# Altium schematic sheet TEST-MODULE.SchDoc(TEST-MODULE)
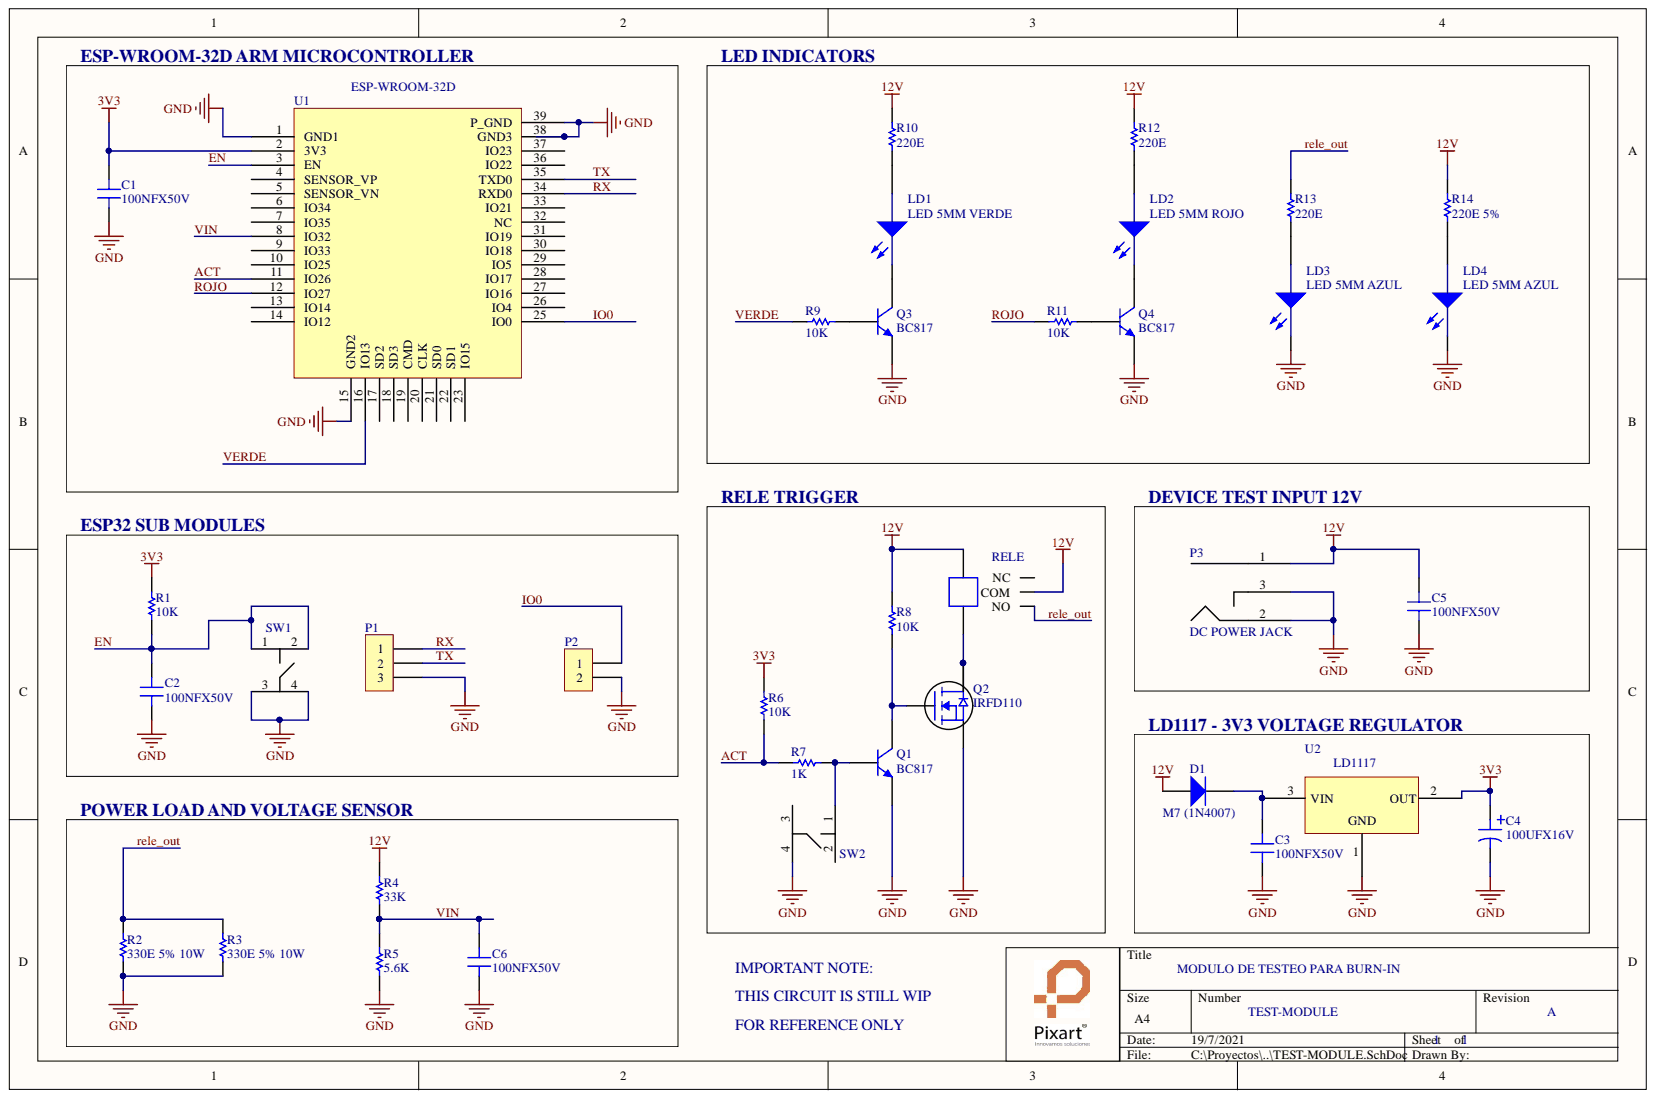
[diagram p0: TEST-MODULE.SchDoc]

## Parts
C1  100NFX50V  [CAP.SMD.0805]
C2  100NFX50V  [CAP.SMD.0805]
C3  100NFX50V  [CAP.SMD.0805]
C4  100UFX16V  [CAP-ELEC-SMD]
C5  100NFX50V  [CAP.SMD.0805]
C6  100NFX50V  [CAP.SMD.0805]
D1  M7 (1N4007)  [Diode-SMD-SMA]
LD1  LED 5MM VERDE  [LED-5MM-ALTO-9-VERDE]
LD2  LED 5MM ROJO  [LED-5MM-ALTO-9-ROJO]
LD3  LED 5MM AZUL  [LED-5MM-ALTO-9-AZUL]
LD4  LED 5MM AZUL  [LED-5MM-ALTO-9-AZUL]
P1  IDC-MA-THT-1X3-P2.54MM-L11  [IDC-MA-THT-1X3-P2.54MM-L11]  (value not drawn)
P2  IDC-MA-THT-1X2-P2.54MM-L11  [IDC-MA-THT-1X2-P2.54MM-L11]  (value not drawn)
P3  DC POWER JACK  [VAR-DC-JACK - VERT]
Q1  BC817  [NPN.SMD.SOT23]
Q2  IRFD110  [TRA-IRFD110]
Q3  BC817  [NPN.SMD.SOT23]
Q4  BC817  [NPN.SMD.SOT23]
R1  10K  [RES.SMD.103]
R2  330E 5% 10W  [RES-CERAMICA-10W]
R3  330E 5% 10W  [RES-CERAMICA-10W]
R4  33K  [RES.SMD.333]
R5  5.6K  [RES.SMD.562]
R6  10K  [RES.SMD.103]
R7  1K  [RES.SMD.102]
R8  10K  [RES.SMD.103]
R9  10K  [RES.SMD.103]
R10  220E  [RES.SMD.221]
R11  10K  [RES.SMD.103]
R12  220E  [RES.SMD.221]
R13  220E  [RES.SMD.221]
R14  220E 5%  [RES.SMD.221]
RELE  Relay simple inversor 12V 12A  [RELAY 12V 12A CHICO]  (value not drawn)
SW1  TACT-SWITCH-THT-4C-06X06(5MM)  [TACT-SWITCH-THT-4C-06X06-5MM]  (value not drawn)
SW2  TACT-SWITCH-THT-4C-06X06(13MM)-JIANFU  [TACT-SWITCH-THT-4C-06X06-13MM]  (value not drawn)
U1  ESP32-WROOM-32D  [ESP32-WROOM-32D]  (value not drawn)
U2  LD1117S33TR SMD DPAK 0.8A 3.3V LDO LINEAR REGULATOR  [DPAK]  (value not drawn)

## Nets
3V3: C1.2 C4.2 R1.2 R6.2 U1.2(3V3) U2.2
12V: C5.2 D1.A P3.1 R4.2 R8.2 R10.2 R12.2 R14.2 RELE.1 RELE.3(COM)
GND: C1.1 C2.1 C3.1 C4.1 C5.1 C6.1 LD3.K LD4.K P1.3 P2.2 P3.2 P3.3 Q1.2 Q2.4 Q3.2 Q4.2 R2.1 R3.1 R5.1 SW1.3 SW1.4 SW2.4 U1.1(GND1) U1.15(GND2) U1.38(GND3) U1.39(P_GND) U2.1
ACT: R6.1 R7.1 U1.11(IO26)
EN: C2.2 R1.1 SW1.1 SW1.2 U1.3(EN)
IO0: P2.1 U1.25(IO0)
rele_out: R2.2 R3.2 R13.2 RELE.4(NO)
ROJO: R11.2 U1.12(IO27)
RX: P1.1 U1.34(RXD0)
TX: P1.2 U1.35(TXD0)
VERDE: R9.1 U1.16(IO13)
VIN: C6.2 R4.1 R5.2 U1.8(IO32)
NetC3_2: C3.2 D1.K U2.3
NetLD1_A: LD1.A R10.1
NetLD1_K: LD1.K Q3.3
NetLD2_A: LD2.A R12.1
NetLD2_K: LD2.K Q4.3
NetLD3_A: LD3.A R13.1
NetLD4_A: LD4.A R14.1
NetQ1_1: Q1.1 R7.2 SW2.1
NetQ1_3: Q1.3 Q2.3 R8.1
NetQ2_1: Q2.1 Q2.2 RELE.2
NetQ3_1: Q3.1 R9.2
NetQ4_1: Q4.1 R11.1

Not connected: RELE 5(NC); SW2 2 3; U1 4(SENSOR_VP) 5(SENSOR_VN) 6(IO34) 7(IO35) 9(IO33) 10(IO25) 13(IO14) 14(IO12) 17(SD2) 18(SD3) 19(CMD) 20(CLK) 21(SD0) 22(SD1) 23(IO15) 26(IO4) 27(IO16) 28(IO17) 29(IO5) 30(IO18) 31(IO19) 32(NC) 33(IO21) 36(IO22) 37(IO23)

## Library data of the parts
C1-C3 C5 C6 = Capacitor 100NFX50V X7R 10% CER.MULT. SMD 0805: 100NFX50V X7R 10% CER.MULT. SMD 0805; COD. FV: CE.CAP.0008; COD. ELEMON: CA3279774; Library Name: Libreria-Capacitores.IntLib; Component Kind: Standard
C4 = Capacitor Electrolitico SMD 100UFX16V 20%. 6.3x5.3 ELE.RAD.SMD [R=]: 100UFX16V 20%. 6.3x5.3 ELE.RAD.SMD [R=]; COD. FV: CE.CAP.0006; COD. ELEMON: CA3629130; Library Name: Libreria-Capacitores.IntLib; Component Kind: Standard
D1 = Diodo M7 DIODO 1A 1000V SMD-SMA (1N4007) XYG: M7 DIODO 1A 1000V SMD-SMA (1N4007) XYG; COD. ELEMON: DI1202221; Library Name: Libreria-Diodos.IntLib; Component Kind: Standard
LD1 = LED 5MM VERDE ALTO 9: Library Name: Libreria-LEDs.IntLib; Description: LED 5MM VERDE ALTO 9; Component Kind: Standard
LD2 = LED 5MM ROJO ALTO 9: Library Name: Libreria-LEDs.IntLib; Description: LED 5MM ROJO ALTO 9; Component Kind: Standard
LD3 LD4 = LED 5MM AZUL ALTO 9: Library Name: Libreria-LEDs.IntLib; Description: LED 5MM AZUL ALTO 9; Component Kind: Standard
P1 = IDC-MA-THT-1X3-P2.54MM-L11: COD. ELEMON: CO496C20; Library Name: Libreria-Conectores.IntLib; Description: IDC-MA-THT-1X3-P2.54MM-L11; Component Kind: Standard
P2 = IDC-MA-THT-1X2-P2.54MM-L11: COD. ELEMON: CO496C20; Library Name: Libreria-Conectores.IntLib; Description: IDC-MA-THT-1X2-P2.54MM-L11; Component Kind: Standard
P3 = DC POWER JACK VERTICAL 2MM: Description: DC POWER JACK VERTICAL 2MM; Component Kind: Standard; Library Name: Libreria-Varios.IntLib
Q1 Q3 Q4 = Transistor BC817-40 TRA.NPN 45V0.5A 0.25W SOT23: BC817-40 TRA.NPN 45V0.5A 0.25W SOT23; COD. FV: CE.TRA.0002; COD. ELEMON: TR1723020; Library Name: Libreria-Transistores.IntLib; Component Kind: Standard
Q2 = Transistor MOSFET N IRFD110: COD. FV: CE.TRA.0003; Library Name: Libreria-Transistores.IntLib; Description: Transistor MOSFET N IRFD110; Component Kind: Standard
R1 R6 R8 R9 R11 = Resistencia 10K 5% 0805 1/8W: 10K 5% 0805 1/8W RES.SMD; COD. FV: CE.RES.0014; COD. ELEMON: RS3896245; Component Kind: Standard; Library Name: Libreria-Resistencias.IntLib
R2 R3 = Resistencia Ceramica 330E 5% 10W SE15 RES.CEM: COD. ELEMON: RS4096155; Description: Resistencia Ceramica 330E 5% 10W SE15 RES.CEM; Component Kind: Standard; Library Name: Libreria-Resistencias.IntLib
R4 = Resistencia 33K 5% 0805 1/8W: 33K 5% 0805 1/8W RES.SMD; COD. FV: CE.RES.0015; COD. ELEMON: RS3896275; Component Kind: Standard; Library Name: Libreria-Resistencias.IntLib
R5 = Resistencia 5.6K 5% 0805 1/8W: 5.6K 5% 0805 1/8W RES.SMD; COD. ELEMON: RS3896230; Component Kind: Standard; Library Name: Libreria-Resistencias.IntLib
R7 = Resistencia 1K 5% 0805 1/8W: 1K 5% 0805 1/8W RES.SMD; COD. FV: CE.RES.0012; COD. ELEMON: RS3896185; Component Kind: Standard; Library Name: Libreria-Resistencias.IntLib
R10 R12-R14 = Resistencia 220E 5% 0805 1/8W: 220E 5% 0805 1/8W RES.SMD; COD. ELEMON: RS3896145; Component Kind: Standard; Library Name: Libreria-Resistencias.IntLib
RELE = VAR-RELE-12V Relay simple inversor chico 12V 12A: Description: Relay simple inversor 12V 12A; Component Kind: Standard; Library Name: Libreria-Varios.IntLib
SW1 = TACT-SWITCH-THT-4C-06X06(5MM): COD. ELEMON: SW5702101; Library Name: Libreria-Switches.IntLib; Description: TACT-SWITCH-THT-4C-06X06(5MM); Component Kind: Standard
SW2 = TACT-SWITCH-THT-4C-06X06(13MM)-JIANFU: COD. ELEMON: SW5702124; Library Name: Libreria-Switches.IntLib; Description: TACT-SWITCH-THT-4C-06X06(13MM)-JIANFU; Component Kind: Standard
U1 = ESP32-WROOM-32D: COD. ELEMON: RF9301435; Description: ESP32-WROOM-32D; Component Kind: Standard; Library Name: Libreria-Semiconductores.IntLib
U2 = LD1117S33TR SMD DPAK 0.8A 3.3V LDO LINEAR REGULATOR: Description: LD1117S33TR SMD DPAK 0.8A 3.3V LDO LINEAR REGULATOR; Component Kind: Standard; Library Name: Libreria-Semiconductores.IntLib
